# Altium schematic sheet TE0747.SchDoc(TE0747)
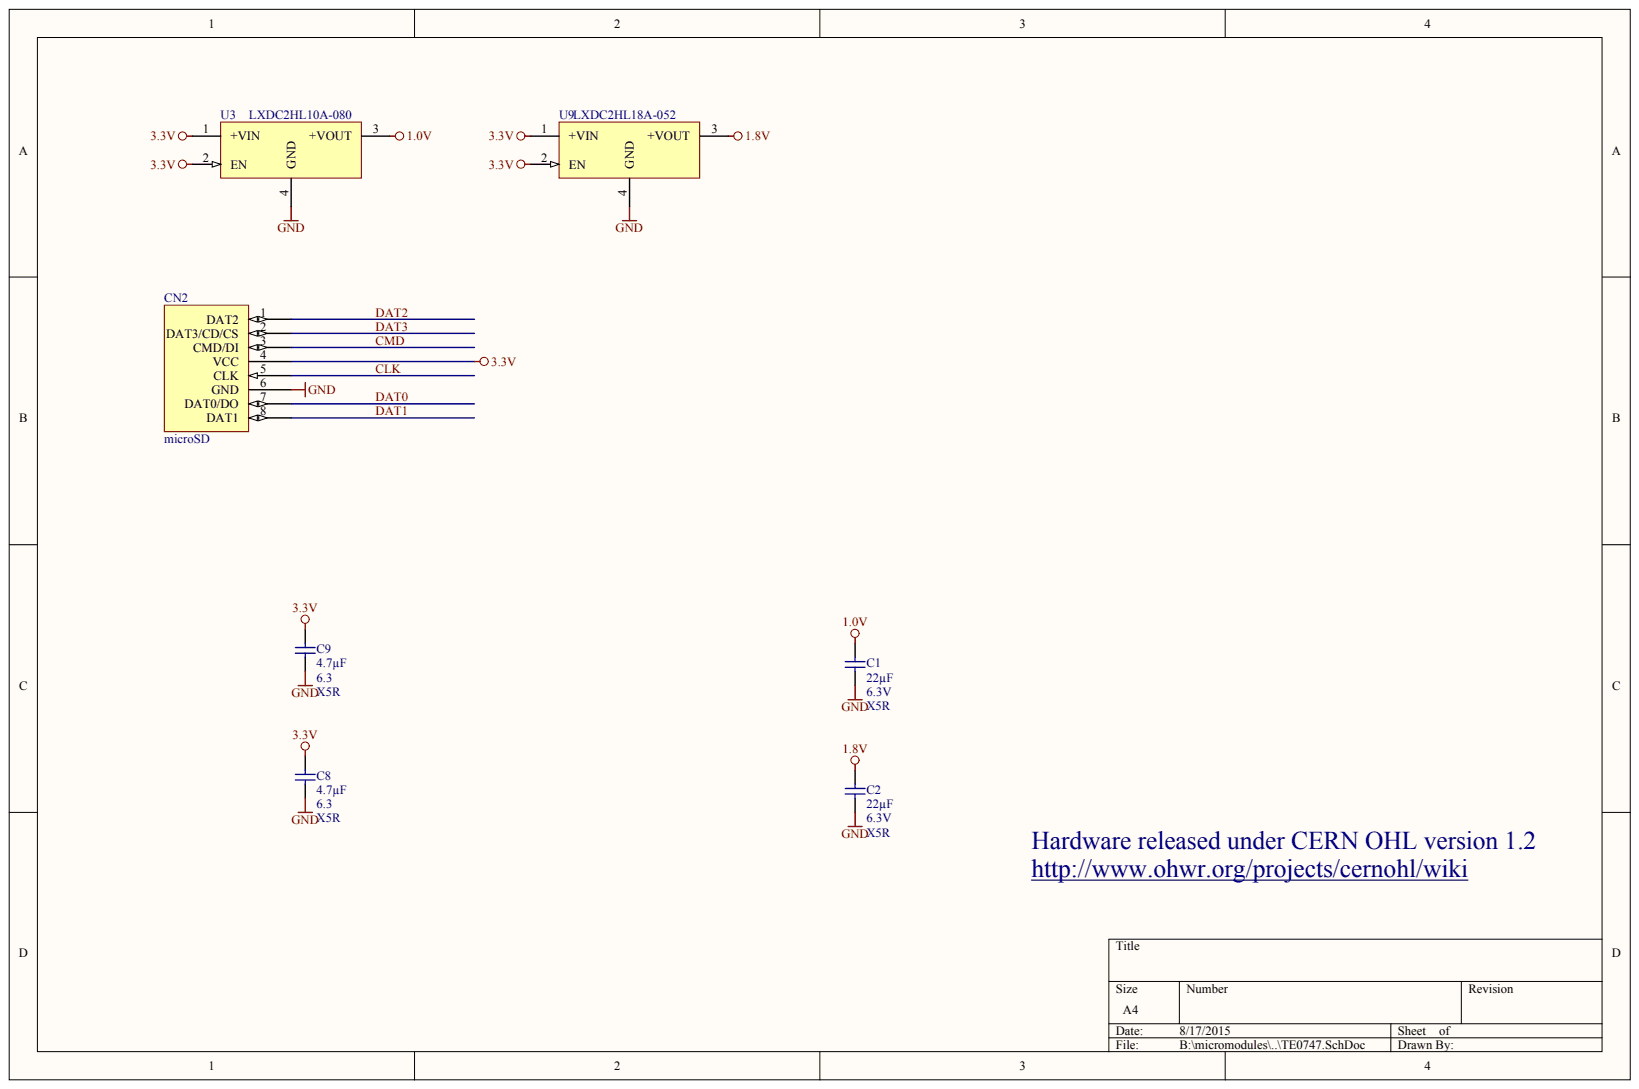
[diagram p0: TE0747.SchDoc]

## Parts
C1  22µF  Technology: X5R  Voltage: 6.3V  [CAPC1608X90N]
C2  22µF  Technology: X5R  Voltage: 6.3V  [CAPC1608X90N]
C8  4.7µF  Technology: X5R  Voltage: 6.3  [CAPC1005X60N]
C9  4.7µF  Technology: X5R  Voltage: 6.3  [CAPC1005X60N]
CN2  microSD  [uSD_PCB]
U3  LXDC2HL10A-080  [LXDC2HL]
U9  LXDC2HL18A-052  [LXDC2HL]

## Nets
1.0V: C1.1 U3.3(+VOUT)
1.8V: C2.1 U9.3(+VOUT)
3.3V: C8.1 C9.1 CN2.4(VCC) U3.1(+VIN) U3.2(EN) U9.1(+VIN) U9.2(EN)
GND: C1.2 C2.2 C8.2 C9.2 CN2.6(GND) U3.4(GND) U9.4(GND)
CLK: CN2.5(CLK)
CMD: CN2.3(CMD/DI)
DAT0: CN2.7(DAT0/DO)
DAT1: CN2.8(DAT1)
DAT2: CN2.1(DAT2)
DAT3: CN2.2(DAT3/CD/CS)

## Library data of the parts
C1 C2 = 25400: KON 22µF 6,3V X5R SMD; Database Table Name: C_1608_0603; Mixed: SMT; Value: 22u; AN: 25400; Case: 1608 (0603); Component Kind: Standard; Library Name: TE.DbLib
C8 C9 = 24719: KON 4.7µF 6.3V X5R SMD 20%; Database Table Name: C_1005_0402; Mixed: SMT; Preferred: Yes; Value: 4.7u; AN: 24719; Case: 1005 (0402); Component Kind: Standard; Library Name: TE.DbLib; Tolerance: 20%
CN2 = microSD_pads: Component Kind: Standard; Description: microSD; Library Name: uUD-normal_template.SCHLIB
U3 = 26786: Database Table Name: DCDC; Mixed: SMT; Trenz-Stock: Yes; AN: 26786; Component Kind: Standard; Description: LXDC2HL10A-080; Library Name: TE.DbLib; HelpURL: B:\AD\adlib\datasheet\LXDC2HL
U9 = 26785: Database Table Name: DCDC; Mixed: SMT; Trenz-Stock: Yes; AN: 26785; Component Kind: Standard; Description: LXDC2HL18A-052; Library Name: TE.DbLib; HelpURL: B:\AD\adlib\datasheet\LXDC2HL
